# Altium schematic sheet Controller.SchDoc
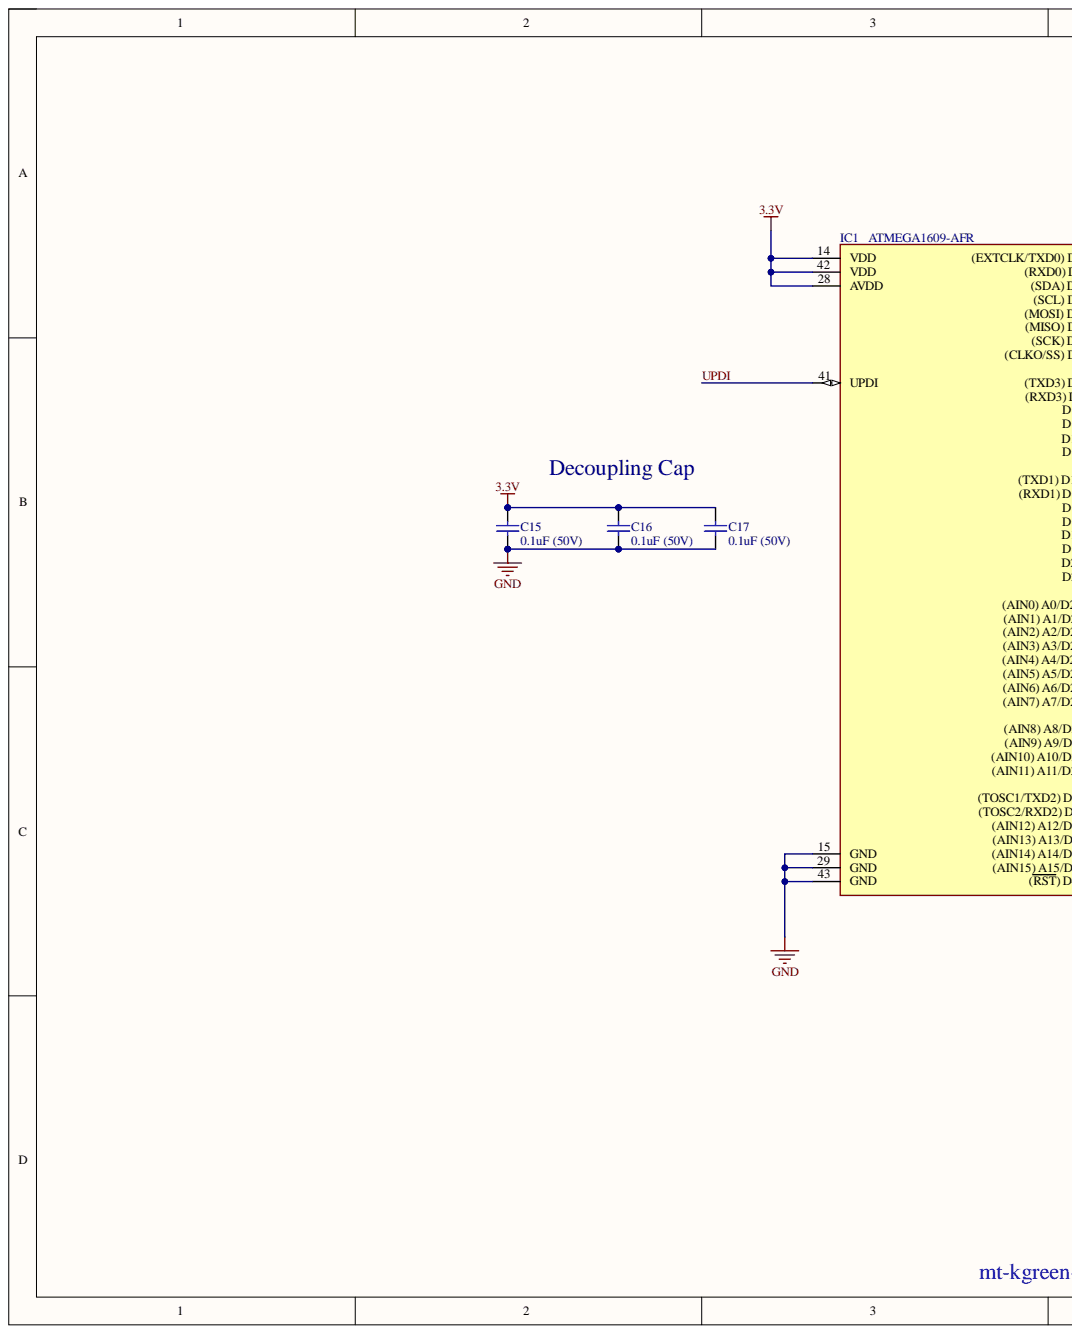
[diagram p0: Controller.SchDoc, left]
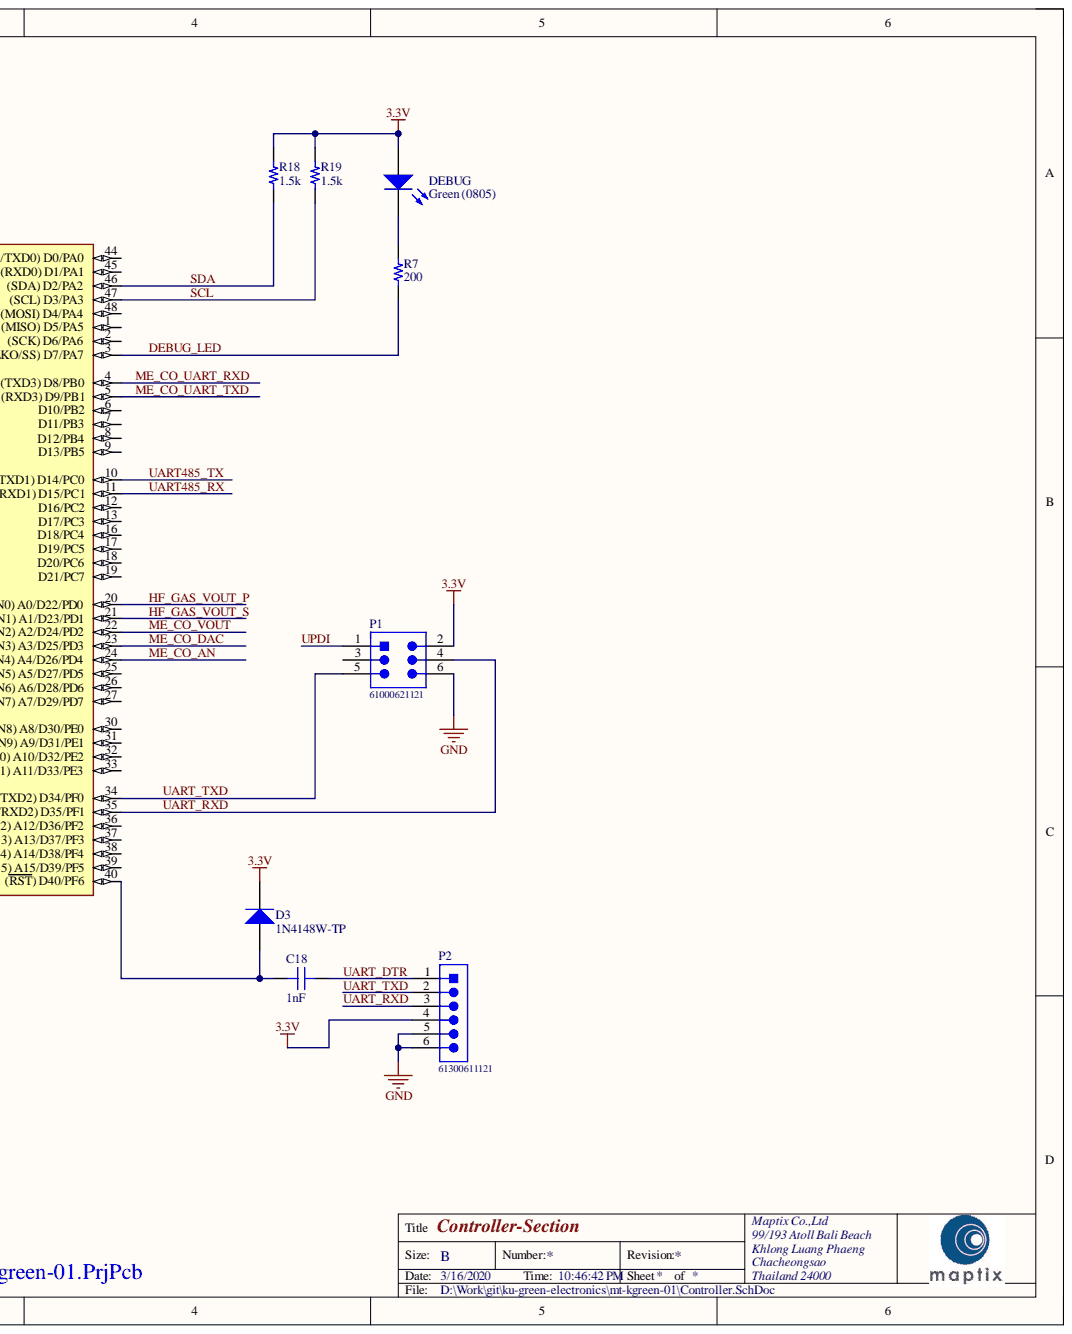
[diagram p1: Controller.SchDoc, right]

## Parts
C15  0.1uF (50V)  [CAPC1608X95X40NL15T15]
C16  0.1uF (50V)  [CAPC1608X95X40NL15T15]
C17  0.1uF (50V)  [CAPC1608X95X40NL15T15]
C18  1nF  [CAP 0603_1608]
D3  1N4148W-TP  [MCC-SOD123_V]
DEBUG  Green (0805)  Color: Green  [0805_A]
IC1  ATMEGA1609-AFR  [QFP50P900X900X120-48N]
P1  61000621121  [61000621121]
P2  61300611121  [61300611121]
R7  200  [RES 0603_1608]
R18  1.5k  [RES 0603_1608]
R19  1.5k  [RES 0603_1608]

## Nets
3.3V: C15.2 C16.2 C17.2 D3.2 DEBUG.2 IC1.14(VDD) IC1.28(AVDD) IC1.42(VDD) P1.2 P2.4 R18.1 R19.1
GND: C15.1 C16.1 C17.1 IC1.15(GND) IC1.29(GND) IC1.43(GND) P1.6 P2.5 P2.6
DEBUG_LED: IC1.3((CLKO/SS)_D7/PA7) R7.1
HF_GAS_VOUT_P: IC1.20((AIN0)_A0/D22/PD0)
HF_GAS_VOUT_S: IC1.21((AIN1)_A1/D23/PD1)
ME_CO_AN: IC1.24((AIN4)_A4/D26/PD4)
ME_CO_DAC: IC1.23((AIN3)_A3/D25/PD3)
ME_CO_UART_RXD: IC1.4((TXD3)_D8/PB0)
ME_CO_UART_TXD: IC1.5((RXD3)_D9/PB1)
ME_CO_VOUT: IC1.22((AIN2)_A2/D24/PD2)
SCL: IC1.47((SCL)_D3/PA3) R19.2
SDA: IC1.46((SDA)_D2/PA2) R18.2
UART485_RX: IC1.11((RXD1)_D15/PC1)
UART485_TX: IC1.10((TXD1)_D14/PC0)
UART_DTR: C18.1 P2.1
UART_RXD: IC1.35((TOSC2/RXD2)_D35/PF1) P1.4 P2.3
UART_TXD: IC1.34((TOSC1/TXD2)_D34/PF0) P1.5 P2.2
UPDI: IC1.41(UPDI) P1.1
NetC18_2: C18.2 D3.1 IC1.40((RST)_D40/PF6)
NetDEBUG_1: DEBUG.1 R7.2

Not connected: IC1 1((MISO)_D5/PA5) 2((SCK)_D6/PA6) 6(D10/PB2) 7(D11/PB3) 8(D12/PB4) 9(D13/PB5) 12(D16/PC2) 13(D17/PC3) 16(D18/PC4) 17(D19/PC5) 18(D20/PC6) 19(D21/PC7) 25((AIN5)_A5/D27/PD5) 26((AIN6)_A6/D28/PD6) 27((AIN7)_A7/D29/PD7) 30((AIN8)_A8/D30/PE0) 31((AIN9)_A9/D31/PE1) 32((AIN10)_A10/D32/PE2) 33((AIN11)_A11/D33/PE3) 36((AIN12)_A12/D36/PF2) 37((AIN13)_A13/D37/PF3) 38((AIN14)_A14/D38/PF4) 39((AIN15)_A15/D39/PF5) 44((EXTCLK/TXD0)_D0/PA0) 45((RXD0)_D1/PA1) 48((MOSI)_D4/PA4); P1 3

## Library data of the parts
C15-C17 = CMP-2006-00072-1: Manufacturer: Vitramon; Part Number: VJ0603Y332JXACW1BC; Component Kind: Standard; Library Name: Altium Content Vault
C18 = VJ0603Y332JXACW1BC: CAP CERAMIC 50V X7R 0603; Manufacturer: Vishay Vitramon; Capacitance: 3300pF; Database Table Name: Capacitors - Ceramic - 0603; Tolerance: ±5%; Applications: General Purpose; Component Kind: Standard; ComponentLink1Description: Datasheet; ComponentLink1URL: https://www.vishay.com/docs/28548/vjw1bcbascomseries.pdf; ComponentLink2Description: DigiKey Link; ComponentLink2URL: http://digikey.com/product-detail/en/vishay-vitramon/VJ0603Y332JXACW1BC/720-VJ0603Y332JXACW1BCCT-ND/11198425; Device Package: 0603 (1608 Metric); LastUpdated: 1/2/2020 12:01:35 AM; Library Name: Celestial Altium Library - altium_library.DbLib; Lifecycle Status: Active; Minimum Order: 1; Mounting Type: Surface Mount, MLCC; Operating Temperature: -55°C ~ 125°C; Package / Case: 0603 (1608 Metric); Packaging: Unknown; Part Number: VJ0603Y332JXACW1BC; Part Status: Active; PartId: 26247; Price: 0.00; Series: VJ; Size / Dimension: 0.063" L x 0.031" W (1.60mm x 0.80mm); Supplier 1: DigiKey; Supplier Part Number 1: 720-VJ0603Y332JXACW1BCCT-ND; Temperature Coefficient: X7R; Thickness (Max): 0.034" (0.87mm); Value: 1nF; Voltage - Rated: 50V
D3 = CMP-2000-04993-1: High Speed Switching Diode, 400 mW, 150 mA, -65 to 150 degC, 2-Pin SOD123, RoHS, Tape and Reel; Manufacturer: Micro Commercial Components; Average Forward Current-Max: 0.15A; Peak Current-Max: 2A; Power Dissipation: 400mW; Reverse Recovery Time-Max: 4ns; Reverse Voltage-Max [Vrrm]: 100V; Component Kind: Standard; Datasheet URL: https://datasheet.ciiva.com/16836/1n4148wsod123-16836277.pdf; Datasheet Version: Rev. C, 07/2014; Library Name: Altium Content Vault; Manufacturer URL: http://www.mccsemi.com/; Package Description: 2-Pin Small Outline Diode, Body 2.7 x 1.6 mm, Height 1.35 mm; Package Reference: SOD123; Package URL: http://www.mccsemi.com/up_pdf/1N4448W(SOD-123).pdf
DEBUG = CMP-1426-00007-1: SMD mono-color Chip LED, WL-SMCW, Green; Manufacturer: Wuerth Elektronik; PartNumber: 150080GS75000; Component Kind: Standard; ComponentLink1Description: Manufacturer URL; ComponentLink1URL: http://www.we-online.com; ComponentLink2Description: Datasheet; ComponentLink2URL: http://katalog.we-online.com/led/datasheet/150080GS75000.pdf; Library Name: Altium Content Vault; PackageReference: 0805_A
IC1 = ATMEGA1609-AFR: Integrated Circuit; Manufacturer_Name: Microchip; Component Kind: Standard; Datasheet Link: https://componentsearchengine.com/Datasheets/1/ATMEGA1609-AFR.pdf; Height: 1.2mm; Library Name: SamacSys.SchLib; Manufacturer_Part_Number: ATMEGA1609-AFR; Mouser Part Number: 579-ATMEGA1609-AFR; Mouser Price/Stock: https://www.mouser.com/Search/Refine.aspx?Keyword=579-ATMEGA1609-AFR
P1 = CMP-1502-01024-1: SMT Vertical Pin Header WR-PHD, Pitch 2.54 mm, Dual Row, 6 pins; Manufacturer: Wurth Elektronik; Contact Resistance: 20mOhm; Gender: Male; Pin Number: 6; Pitch: 2.54mm; Rated Current: 3A; Withstanding Voltage: 500VAC; Working Voltage: 250VAC; Component Kind: Standard; Datasheet URL: https://katalog.we-online.de/em/datasheet/6100xx21121.pdf; Library Name: Altium Content Vault; Manufacturer URL: http://www.we-online.de; Mounting Technology: SMT; Operating Temperature: -40to125degC; Orientation: Vertical; Package Reference: 61000621121; Packaging: Tube; Part Number: 61000621121; Row Number: Dual; Publisher: WurthElektronik
P2 = CMP-1502-01070-1: THT Vertical Pin Header WR-PHD, Pitch 2.54 mm, Single Row, 6 pins; Manufacturer: Wurth Elektronik; Contact Resistance: 20mOhm; Gender: Male; Pin Number: 6; Pitch: 2.54mm; Rated Current: 3A; Withstanding Voltage: 500VAC; Working Voltage: 250VAC; Component Kind: Standard; Datasheet URL: https://katalog.we-online.de/em/datasheet/6130xx11121.pdf; Library Name: Altium Content Vault; Manufacturer URL: http://www.we-online.de; Mounting Technology: THT; Operating Temperature: -40to105degC; Orientation: Vertical; Package Reference: 61300611121; Packaging: Bag; Part Number: 61300611121; Row Number: Single; Publisher: WurthElektronik
R7 R18 R19 = CRCW060329K4FKEA: Resistor; Manufacturer: Vishay Dale; Database Table Name: Resistors - Surface Mount - 0603; Power (Watts): 0.1W, 1/10W; Resistance: 29.4 kOhms; Temperature Coefficient: ±100ppm/°C; Tolerance: ±1%; Component Kind: Standard; ComponentLink1Description: Datasheet; ComponentLink1URL: http://www.vishay.com/docs/20035/dcrcwe3.pdf; ComponentLink2Description: DigiKey Link; ComponentLink2URL: http://digikey.com/product-detail/en/vishay-dale/CRCW060329K4FKEA/541-29.4KHCT-ND/1179975; Composition: Thick Film; Device Package: 0603 (1608 Metric); Features: Automotive AEC-Q200; Height - Seated (Max): 0.020" (0.50mm); LastUpdated: 1/2/2020 12:06:58 AM; Library Name: Celestial Altium Library - altium_library.DbLib; Lifecycle Status: Active; Minimum Order: 1; Number of Terminations: 2; Operating Temperature: -55°C ~ 155°C; Package / Case: 0603 (1608 Metric); Packaging: TapeAndReel; Part Number: CRCW060329K4FKEA; Part Status: Active; PartId: 7975; Price: 0.00; Ratings: AEC-Q200; Series: CRCW; Size / Dimension: 0.061" L x 0.033" W (1.55mm x 0.85mm); Supplier 1: DigiKey; Supplier Device Package: 0603; Supplier Part Number 1: 541-29.4KHCT-ND
  R7: Value: 200
  R18 R19: Value: 1.5k
